# Altium schematic sheet S7CAS-PLEIADES-CARTE-MERE_P07_FPGA_4-5.SchDoc(S7CAS-PLEIADES-CARTE-MERE_P07_FPGA_4-5)
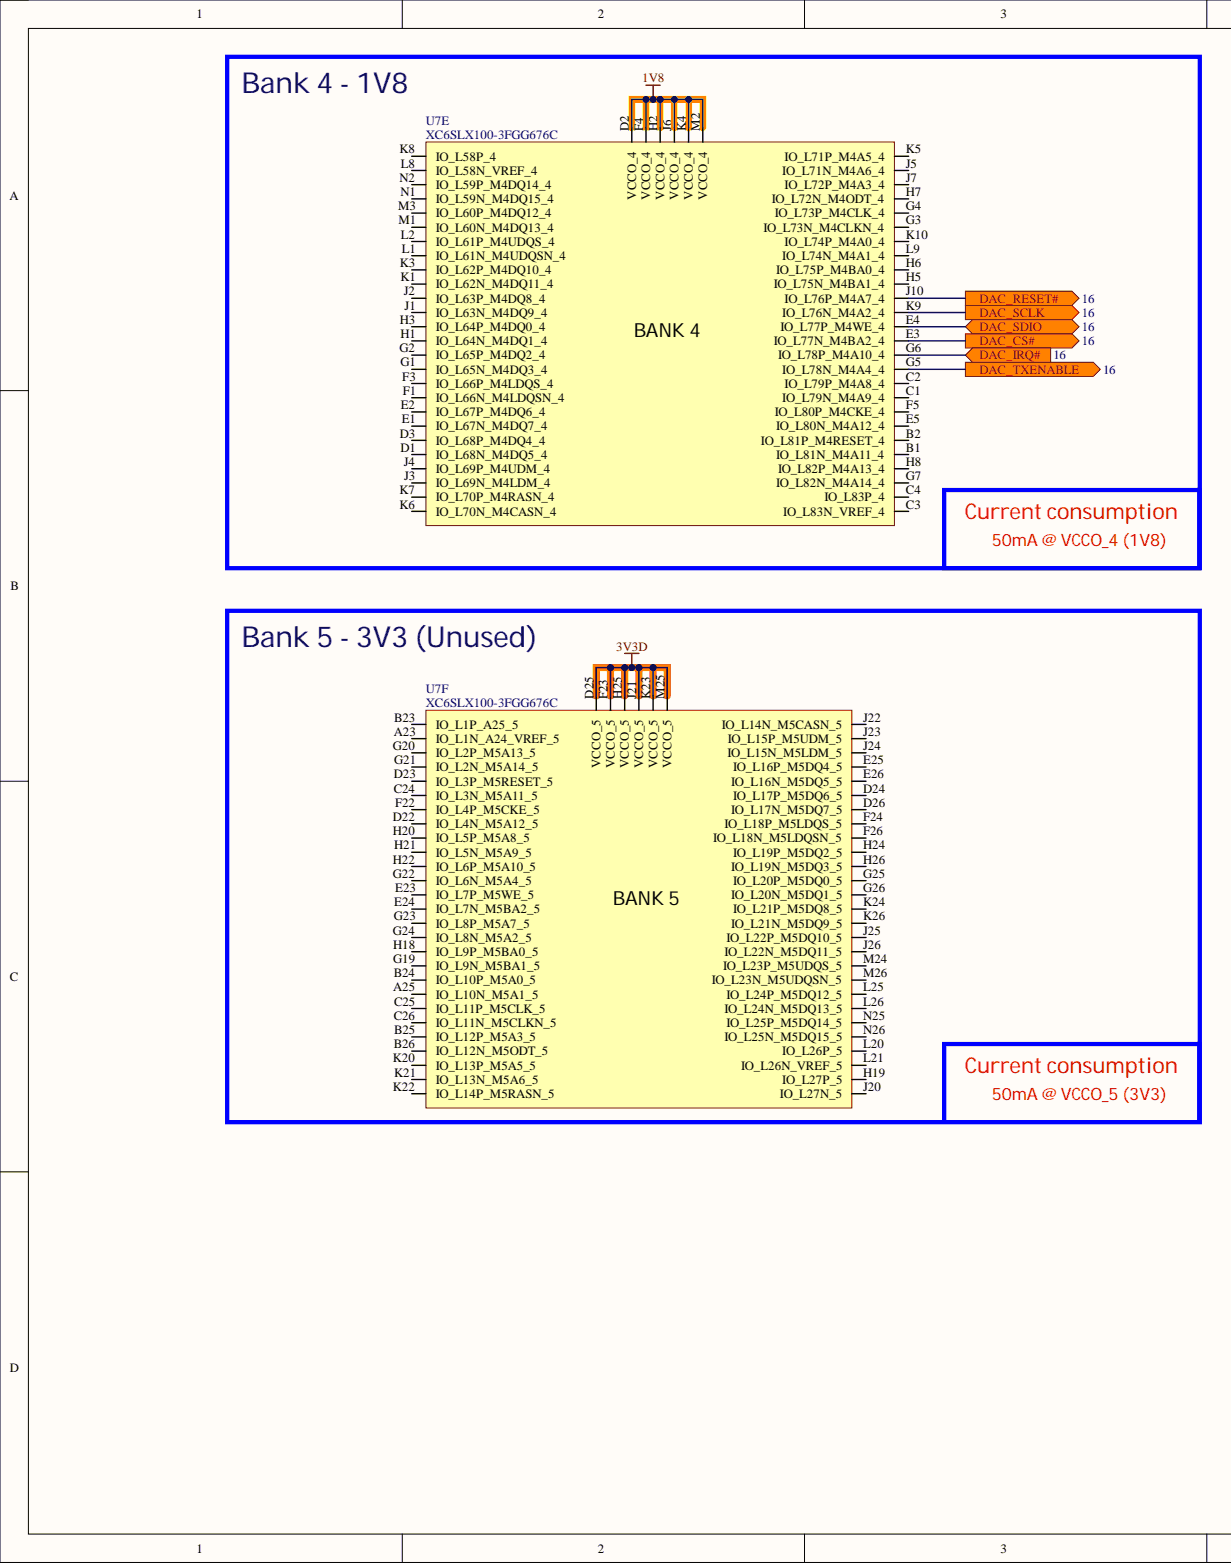
[diagram p0: S7CAS-PLEIADES-CARTE-MERE_P07_FPGA_4-5.SchDoc, left]
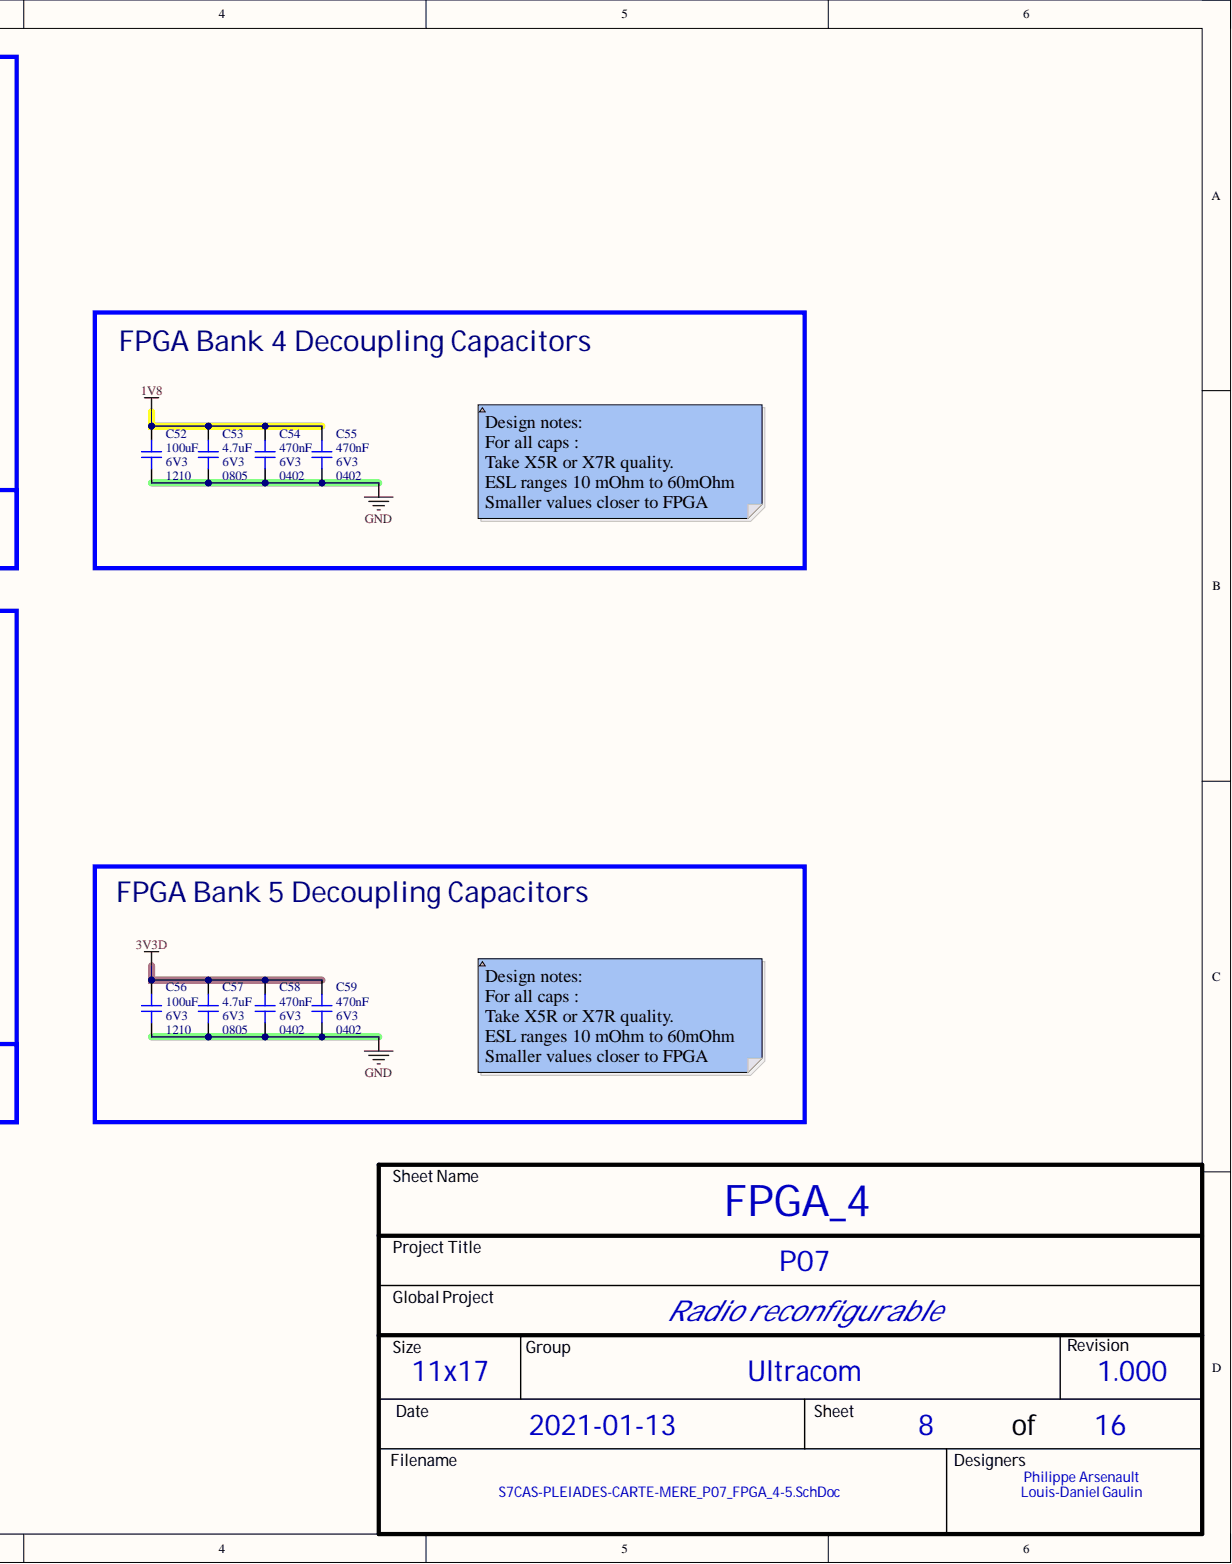
[diagram p1: S7CAS-PLEIADES-CARTE-MERE_P07_FPGA_4-5.SchDoc, right]

## Parts
C52  value 100uF  Package: 1210  Voltage: 6V3  [CAP_1210]  (hidden comment: CAP - 1210)
C53  value 4.7uF  Package: 0805  Voltage: 6V3  [CAP_0805]  (hidden comment: CAP - 0805)
C54  value 470nF  Package: 0402  Voltage: 6V3  [CAP_0402]  (hidden comment: CAP - 0402)
C55  value 470nF  Package: 0402  Voltage: 6V3  [CAP_0402]  (hidden comment: CAP - 0402)
C56  value 100uF  Package: 1210  Voltage: 6V3  [CAP_1210]  (hidden comment: CAP - 1210)
C57  value 4.7uF  Package: 0805  Voltage: 6V3  [CAP_0805]  (hidden comment: CAP - 0805)
C58  value 470nF  Package: 0402  Voltage: 6V3  [CAP_0402]  (hidden comment: CAP - 0402)
C59  value 470nF  Package: 0402  Voltage: 6V3  [CAP_0402]  (hidden comment: CAP - 0402)
U7  XC6SLX100-3FGG676C  [FPGA_XILINX_FGG676]  (parts E,F)

## Ports
DAC_CS#, DAC_IRQ#, DAC_RESET#, DAC_SCLK, DAC_SDIO, DAC_TXENABLE

## Nets
1V8: C52.2 C53.2 C54.2 C55.2 U7.D2(VCCO_4) U7.F4(VCCO_4) U7.H2(VCCO_4) U7.J6(VCCO_4) U7.K4(VCCO_4) U7.M2(VCCO_4)
3V3D: C56.2 C57.2 C58.2 C59.2 U7.D25(VCCO_5) U7.F23(VCCO_5) U7.H25(VCCO_5) U7.J21(VCCO_5) U7.K23(VCCO_5) U7.M25(VCCO_5)
GND: C52.1 C53.1 C54.1 C55.1 C56.1 C57.1 C58.1 C59.1
DAC_CS#: U7.E3(IO_L77N_M4BA2_4) ; port DAC_CS#
DAC_IRQ#: U7.G6(IO_L78P_M4A10_4) ; port DAC_IRQ#
DAC_RESET#: U7.J10(IO_L76P_M4A7_4) ; port DAC_RESET#
DAC_SCLK: U7.K9(IO_L76N_M4A2_4) ; port DAC_SCLK
DAC_SDIO: U7.E4(IO_L77P_M4WE_4) ; port DAC_SDIO
DAC_TXENABLE: U7.G5(IO_L78N_M4A4_4) ; port DAC_TXENABLE

Not connected: U7 A23(IO_L1N_A24_VREF_5) A25(IO_L10N_M5A1_5) B1(IO_L81N_M4A11_4) B2(IO_L81P_M4RESET_4) B23(IO_L1P_A25_5) B24(IO_L10P_M5A0_5) B25(IO_L12P_M5A3_5) B26(IO_L12N_M5ODT_5) C1(IO_L79N_M4A9_4) C2(IO_L79P_M4A8_4) C3(IO_L83N_VREF_4) C4(IO_L83P_4) C24(IO_L3N_M5A11_5) C25(IO_L11P_M5CLK_5) C26(IO_L11N_M5CLKN_5) D1(IO_L68N_M4DQ5_4) D3(IO_L68P_M4DQ4_4) D22(IO_L4N_M5A12_5) D23(IO_L3P_M5RESET_5) D24(IO_L17P_M5DQ6_5) D26(IO_L17N_M5DQ7_5) E1(IO_L67N_M4DQ7_4) E2(IO_L67P_M4DQ6_4) E5(IO_L80N_M4A12_4) E23(IO_L7P_M5WE_5) E24(IO_L7N_M5BA2_5) E25(IO_L16P_M5DQ4_5) E26(IO_L16N_M5DQ5_5) F1(IO_L66N_M4LDQSN_4) F3(IO_L66P_M4LDQS_4) F5(IO_L80P_M4CKE_4) F22(IO_L4P_M5CKE_5) F24(IO_L18P_M5LDQS_5) F26(IO_L18N_M5LDQSN_5) G1(IO_L65N_M4DQ3_4) G2(IO_L65P_M4DQ2_4) G3(IO_L73N_M4CLKN_4) G4(IO_L73P_M4CLK_4) G7(IO_L82N_M4A14_4) G19(IO_L9N_M5BA1_5) G20(IO_L2P_M5A13_5) G21(IO_L2N_M5A14_5) G22(IO_L6N_M5A4_5) G23(IO_L8P_M5A7_5) G24(IO_L8N_M5A2_5) G25(IO_L20P_M5DQ0_5) G26(IO_L20N_M5DQ1_5) H1(IO_L64N_M4DQ1_4) H3(IO_L64P_M4DQ0_4) H5(IO_L75N_M4BA1_4) H6(IO_L75P_M4BA0_4) H7(IO_L72N_M4ODT_4) H8(IO_L82P_M4A13_4) H18(IO_L9P_M5BA0_5) H19(IO_L27P_5) H20(IO_L5P_M5A8_5) H21(IO_L5N_M5A9_5) H22(IO_L6P_M5A10_5) H24(IO_L19P_M5DQ2_5) H26(IO_L19N_M5DQ3_5) J1(IO_L63N_M4DQ9_4) J2(IO_L63P_M4DQ8_4) J3(IO_L69N_M4LDM_4) J4(IO_L69P_M4UDM_4) J5(IO_L71N_M4A6_4) J7(IO_L72P_M4A3_4) J20(IO_L27N_5) J22(IO_L14N_M5CASN_5) J23(IO_L15P_M5UDM_5) J24(IO_L15N_M5LDM_5) J25(IO_L22P_M5DQ10_5) J26(IO_L22N_M5DQ11_5) K1(IO_L62N_M4DQ11_4) K3(IO_L62P_M4DQ10_4) K5(IO_L71P_M4A5_4) K6(IO_L70N_M4CASN_4) K7(IO_L70P_M4RASN_4) K8(IO_L58P_4) K10(IO_L74P_M4A0_4) K20(IO_L13P_M5A5_5) K21(IO_L13N_M5A6_5) K22(IO_L14P_M5RASN_5) K24(IO_L21P_M5DQ8_5) K26(IO_L21N_M5DQ9_5) L1(IO_L61N_M4UDQSN_4) L2(IO_L61P_M4UDQS_4) L8(IO_L58N_VREF_4) L9(IO_L74N_M4A1_4) L20(IO_L26P_5) L21(IO_L26N_VREF_5) L25(IO_L24P_M5DQ12_5) L26(IO_L24N_M5DQ13_5) M1(IO_L60N_M4DQ13_4) M3(IO_L60P_M4DQ12_4) M24(IO_L23P_M5UDQS_5) M26(IO_L23N_M5UDQSN_5) N1(IO_L59N_M4DQ15_4) N2(IO_L59P_M4DQ14_4) N25(IO_L25P_M5DQ14_5) N26(IO_L25N_M5DQ15_5)

## Library data of the parts
C52 C56 = CAP - 1210: Precision: 10%; Component Kind: Standard; Description: CAP - 1210; Library Name: S7CAS_LIB2021.IntLib; CreatedBy: Jonathan Bouchard
C53 C57 = CAP - 0805: Precision: 10%; Component Kind: Standard; Description: CAP - 0805; Library Name: S7CAS_LIB2021.IntLib; CreatedBy: Jonathan Bouchard
C54 C55 C58 C59 = CAP - 0402: Precision: 10%; Component Kind: Standard; Description: CAP - 0402; Library Name: S7CAS_LIB2021.IntLib; CreatedBy: Jonathan Bouchard
U7 = FPGA_XC6SLX100-3FGG676C: FPGA - XILINX SPARTAN 6 480 I/O - BGA-676; Voltage: 1.14 V ~ 1.26 V; Component Kind: Standard; HelpURL: https://www.xilinx.com/support/documentation/data_sheets/ds162.pdf; Library Name: S7CAS_LIB2021.IntLib; Mfg: Xilinx; Mfg Part Number: XC6SLX100-3FGG676C; Package: BGA-676; Supplier 1: Digikey; Supplier Part Number 1: 122-1760-ND; Temperature: 0°C ~ 85°C (TJ); CreatedBy: Jonathan Bouchard
